# Altium schematic sheet PreampTX.SchDoc
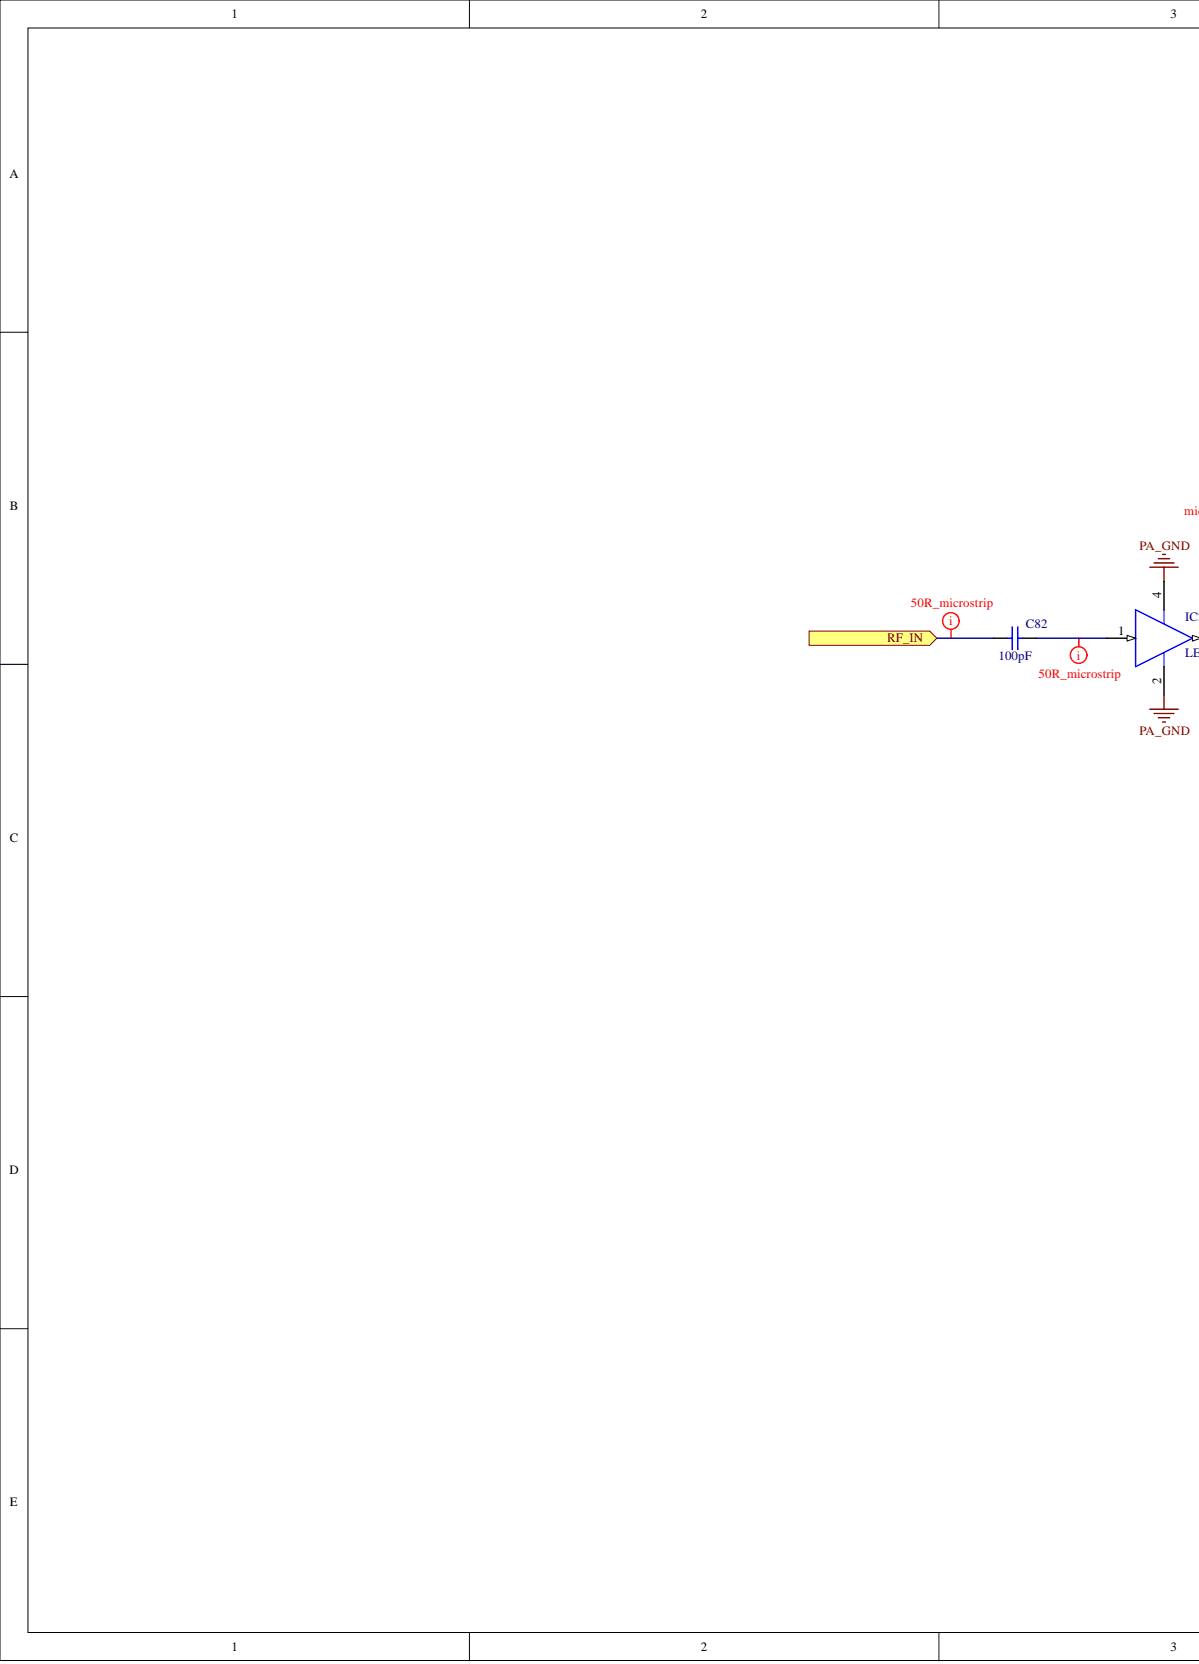
[diagram p0: PreampTX.SchDoc, left]
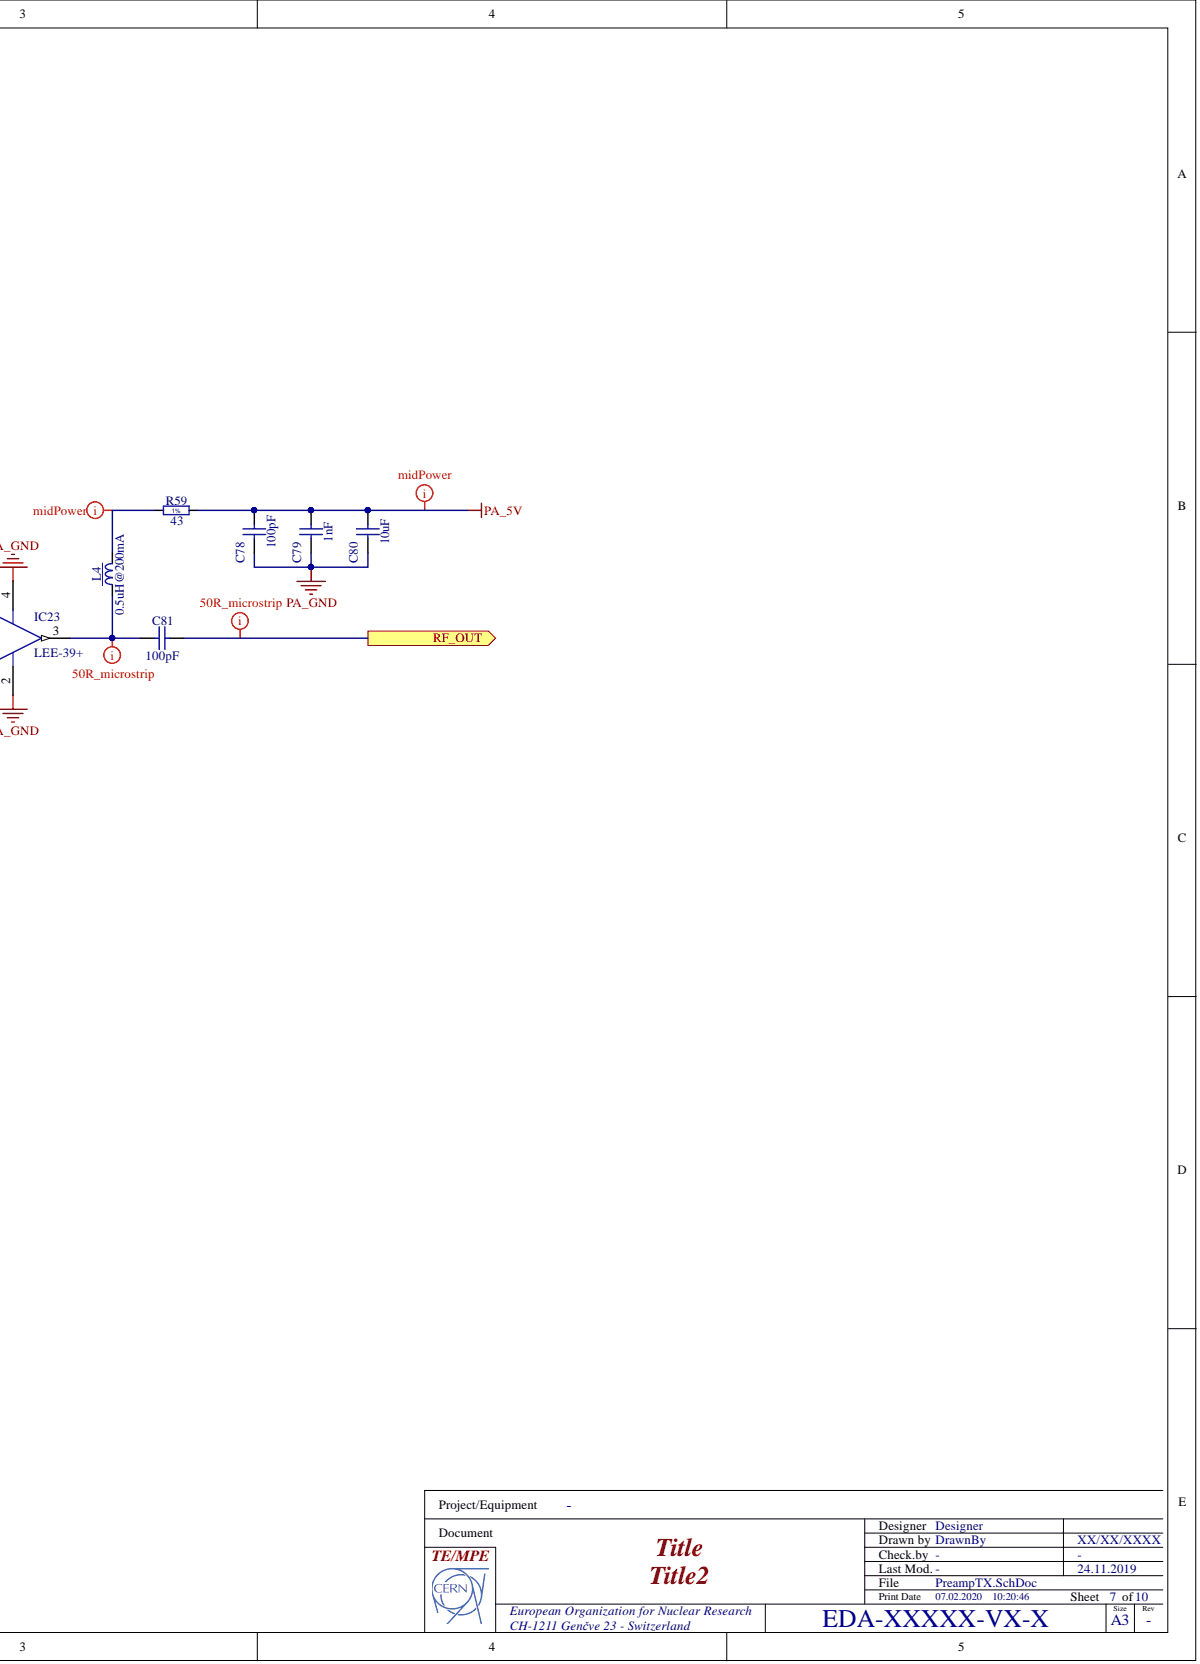
[diagram p1: PreampTX.SchDoc, right]

## Parts
C78  100pF  [CAPC1005X55N]
C79  1nF  [CAPC1005X55N]
C80  10uF  [CAPC3216X180N]
C81  100pF  [CAPC1005X55N]
C82  100pF  [CAPC1005X55N]
IC23  LEE-39+  [MINI-CIRCUITS_FG873]
L4  0.5uH@200mA  [IND_MINI-CIRCUITS_GU1604]
R59  43  [RESC1608X55N]

## Ports
RF_IN, RF_OUT

## Nets
PA_5V: C78.2 C79.2 C80.2 R59.2
PA_GND: C78.1 C79.1 C80.1 IC23.2 IC23.4
RF_IN: C82.1 ; port RF_IN
RF_OUT: C81.2 ; port RF_OUT
NetC81_1: C81.1 IC23.3 L4.1
NetC82_2: C82.2 IC23.1
NetL4_3: L4.3 R59.1

## Library data of the parts
C78 C81 C82 = CC0402_100PF_50V_5%_C0G: Non-polarised capacitor; Manufacturer: GENERIC; Manufacturer1 Part Number: C0402C101J5GACTU; Part Description: SMD Multilayer Chip Ceramic Capacitor; Database Table Name: Capacitors SMD; TC: C0G/NP0; Tolerance: ±5%; Voltage: 50V; Case: 0402; Component Kind: Standard; Component Type: Standard; CreateDate: 03.12.2007; HelpURL: \\cern.ch\dfs\Applications\Altium\Datasheets\CC0805_C0G_KEMET_(Commercial Grade).pdf; LatestRevisionDate: 22.04.2015; Library Name: Capacitors.DbLib; Manufacturer1 ComponentHeight: 0.55mm; Manufacturer1 Example: KEMET; Manufacturer Part Number: CC0402_100PF_50V_5%_C0G; Mounted: Yes; Part Number: CC0402_100PF_50V_5%_C0G; Pin Count: 2; Sense: No; SMD: Yes; Socket: No; Status: Preferred; Value: 100pF; Author: CERN DEM JLC
C79 = CC0402_1NF_50V_10%_X7R: Non-polarised capacitor; Manufacturer: GENERIC; Manufacturer1 Part Number: 223858715623; Part Description: SMD Multilayer Chip Ceramic Capacitor; Database Table Name: Capacitors SMD; TC: X7R; Tolerance: ±10%; Voltage: 50V; Case: 0402; Component Kind: Standard; Component Type: Standard; CreateDate: 03.12.2007; HelpURL: \\cern.ch\dfs\Applications\Altium\Datasheets\CC0402_X7R_PHYCOMP.pdf; LatestRevisionDate: 03.12.2007; Library Name: Capacitors.DbLib; Manufacturer1 ComponentHeight: 0.55mm; Manufacturer1 Example: PHYCOMP; Manufacturer Part Number: CC0402_1NF_50V_10%_X7R; Mounted: Yes; Part Number: CC0402_1NF_50V_10%_X7R; Pin Count: 2; Sense: No; SMD: Yes; Socket: No; Status: Not Recommended; Value: 1nF; Author: CERN DEM JLC
C80 = CC1206_10UF_25V_10%_X7R: Non-polarised capacitor; Manufacturer: GENERIC; Manufacturer1 Part Number: C1206C106K4RACTU; Part Description: SMD Multilayer Chip Ceramic Capacitor; Database Table Name: Capacitors SMD; TC: X7R; Tolerance: ±10%; Voltage: 25V; Case: 1206; Component Kind: Standard; Component Type: Standard; CreateDate: 12.09.2012; HelpURL: \\cern.ch\dfs\Applications\Altium\Datasheets\CC1206_X7R_KEMET.pdf; LatestRevisionDate: 12.09.2012; Library Name: Capacitors.DbLib; Manufacturer1 ComponentHeight: 1.8mm; Manufacturer1 Example: KEMET; Manufacturer Part Number: CC1206_10uF_25V_10%_X7R; Mounted: Yes; Part Number: CC1206_10UF_25V_10%_X7R; Pin Count: 2; Sense: No; SMD: Yes; Socket: No; Status: Preferred; Value: 10uF; Author: CERN DEM JLC
IC23 = LEE-39+: Monolithic Amplifier; Manufacturer: MINI-CIRCUITS; Part Description: DC-8GHz Monolithic Amplifier; Bonding: No; Database Table Name: Operational Amplifiers; PressFit: No; ComponentHeight: 0.89mm; Component Kind: Standard; ComponentLink1Description: Package Drawing; ComponentLink1URL: \\cern.ch\dfs\Applications\Altium\Datasheets\LEE-39+_Package-Drawing.pdf; Component Type: Standard; CreateDate: 17.01.2017; Device: LEE-39+; HelpURL: \\cern.ch\dfs\Applications\Altium\Datasheets\LEE-39+.pdf; LatestRevisionDate: 17.01.2017; Library Name: ICs And Semiconductors.DbLib; Manufacturer Part Number: LEE-39+; Mounted: Yes; PackageDescription: MINI-CIRCUITS FG873 Case, 4 pin, 3.0mm W X 3.0mm L; Part Number: LEE-39+; Pin Count: 4; Sense: No; SMD: Yes; Socket: No; Author: CERN DEM JLC
L4 = IND_MINI-CIRCUITS_TCCH-80+: 50 Ohms, 50 to 8200 MHz RF Choke; Database Table Name: Inductors SMD; Power: 200mA; Resistance: 0.1R; ComponentHeight: 3.81mm; Component Kind: Standard; Component Type: Standard; CreateDate: 19.12.2011; HelpURL: \\cern.ch\dfs\Applications\Altium\Datasheets\IND_MINI-CIRCUITS_TCCH-80+.pdf; LatestRevisionDate: 19.12.2011; Library Name: Inductors & Transformers.DbLib; Manufacturer: MINI-CIRCUITS; Manufacturer Part Number: TCCH-80+; Mounted: Yes; Part Description: 50 Ohms, 50 to 8200 MHz RF Choke; Part Number: IND_MINI-CIRCUITS_TCCH-80+; Pin Count: 4; Sense: No; SMD: Yes; Socket: No; Status: None; Value: 0.5uH@200mA; Author: CERN DEM JLC
R59 = R0603_43R_1%_0.1W_100PPM: Resistor - 1%; Manufacturer: GENERIC; Manufacturer1 Part Number: NRC06F43R0TRF; Part Description: General Purpose Thick Film Chip Resistor; Database Table Name: Resistors SMD; Power: 0.1W; PressFit: No; TC: ±100ppm/°C; Case: 0603; Component Kind: Standard; Component Type: Standard; CreateDate: 03.12.2007; HelpURL: \\cern.ch\dfs\Applications\Altium\Datasheets\R0603_NIC_NRC.pdf; LatestRevisionDate: 13.03.2008; Library Name: Resistors.DbLib; Manufacturer1 ComponentHeight: 0.55mm; Manufacturer1 Example: NIC COMPONENT; Manufacturer Part Number: R0603_43R_1%_0.1W_100PPM; Mounted: Yes; Part Number: R0603_43R_1%_0.1W_100PPM; Pin Count: 2; Sense: No; SMD: Yes; Socket: No; Status: Preferred; Tolerance: ±1%; Value: 43; Author: CERN DEM JLC
